# Altium schematic sheet Usb_To_UART.SchDoc(Usb_To_UART)
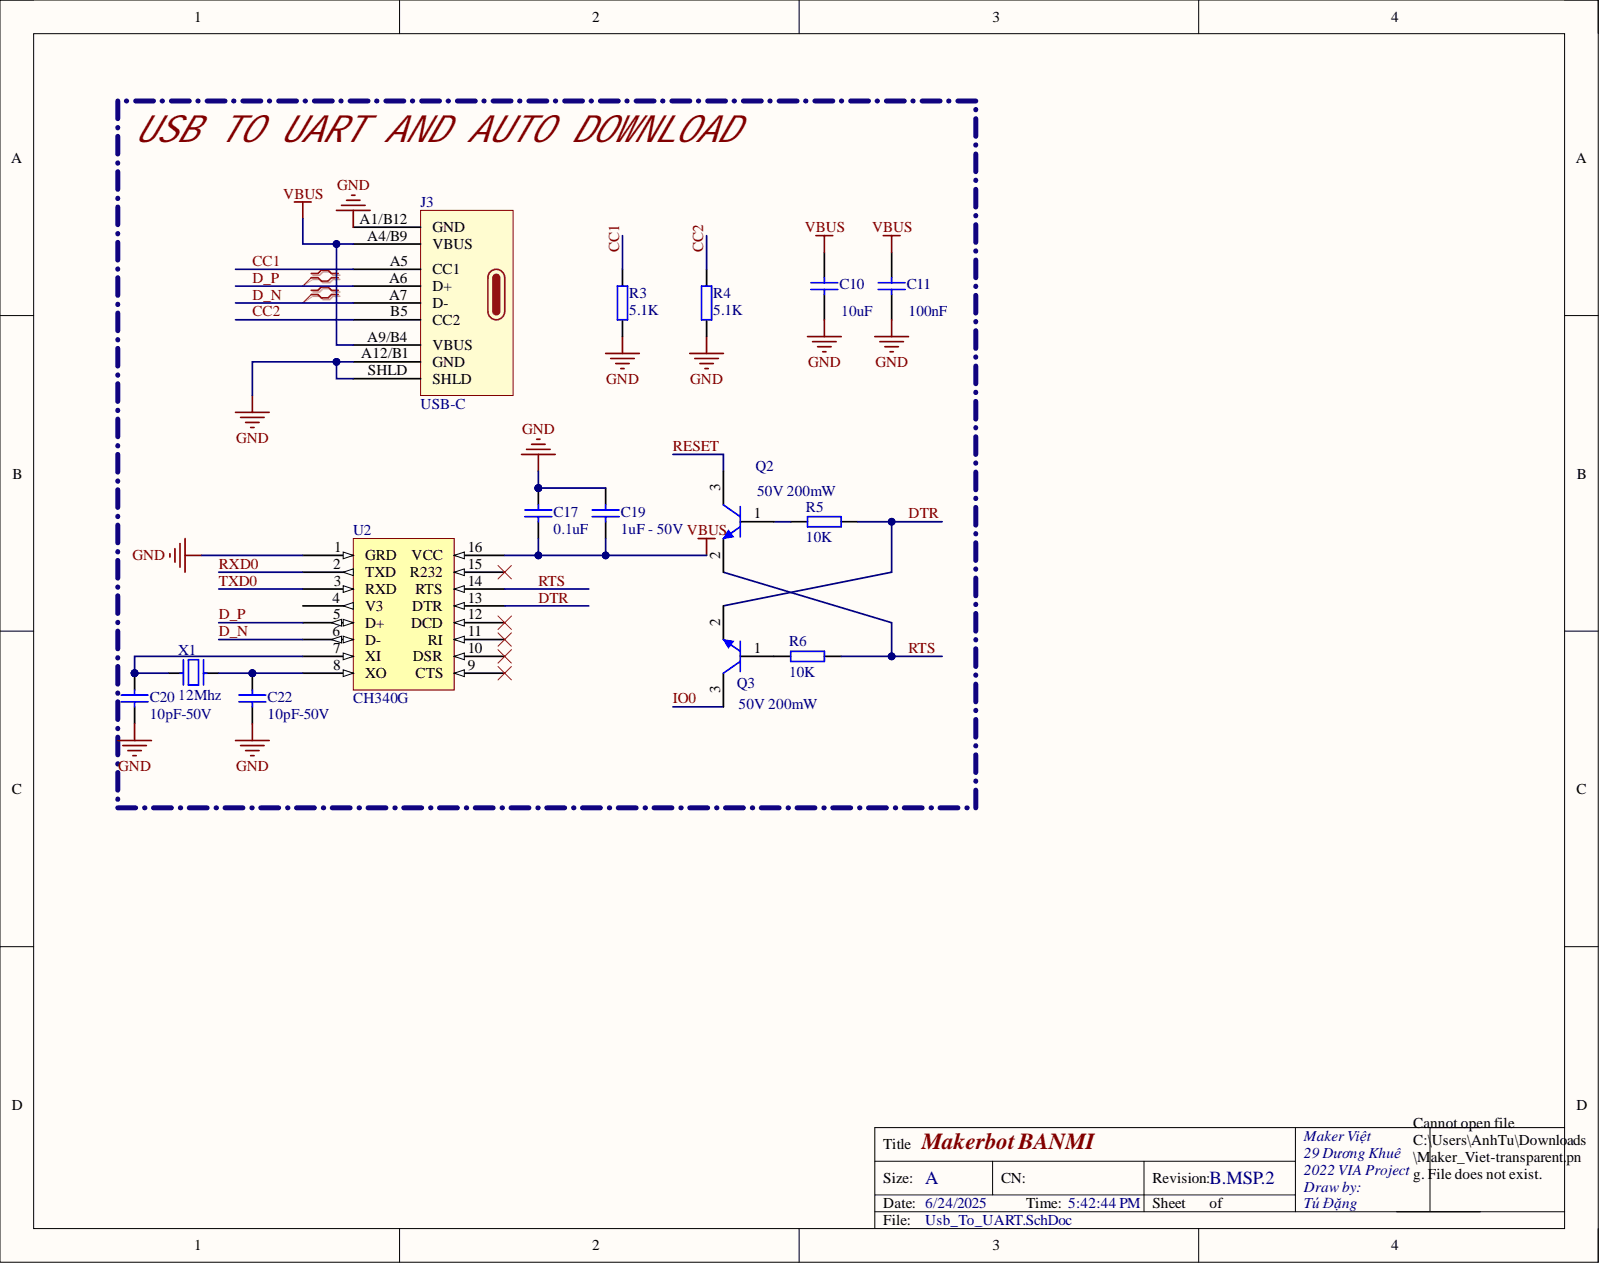
[diagram p0: Usb_To_UART.SchDoc]

## Parts
C10  value 10uF  (hidden comment: Cap Semi)
C11  value 100nF  (hidden comment: Cap Semi)
C17  0.1uF
C19  1uF - 50V
C20  10pF-50V
C22  10pF-50V
J3  USB-C
Q2  50V 200mW
Q3  50V 200mW
R3  value 5.1K  (hidden comment: Resistor)
R4  value 5.1K  (hidden comment: Resistor)
R5  value 10K  (hidden comment: Resistor)
R6  value 10K  (hidden comment: Resistor)
U2  CH340G
X1  12Mhz

## Nets
GND: C10.1 C11.1 C17.2 C19.2 C20.1 C22.1 J3.A1/B12(GND) J3.A12/B1(GND) J3.SHLD R3.2 R4.2 U2.1(GRD)
VBUS: C10.2 C11.2 C17.1 C19.1 J3.A4/B9(VBUS) J3.A9/B4(VBUS) U2.16(VCC)
CC1: J3.A5(CC1) R3.1
CC2: J3.B5(CC2) R4.1
D_N: J3.A7(D-) U2.6(D-)
D_P: J3.A6(D+) U2.5(D+)
DTR: Q3.2 R5.1 U2.13(DTR)
IO0: Q3.3
RESET: Q2.3
RTS: Q2.2 R6.1 U2.14(RTS)
RXD0: U2.2(TXD)
TXD0: U2.3(RXD)
NetC20_2: C20.2 U2.7(XI) X1.3
NetC22_2: C22.2 U2.8(XO) X1.1
NetQ2_1: Q2.1 R5.2
NetQ3_1: Q3.1 R6.2

Not connected: U2 4(V3) 9(CTS) 10(DSR) 11(RI) 12(DCD) 15(R232)

## Library data of the parts
C10 = CAP-SM-0603-X5R-10uF: CAP SM 0603 X5R 10uF 10%; Component Kind: Standard; Library Name: electronpowered.SchLib; Supplier 1: Farnell; Supplier 2: Digi-Key; Supplier Part Number 1: 2366833; Supplier Part Number 2: 490-3896-1-ND; Tolerance: ±10%
C11 = CAP-SM-0603-X7R-100nF: CAP SM 0603 X7R 100nF 10%; Component Kind: Standard; Library Name: electronpowered.SchLib; Supplier 1: Digi-Key; Supplier 2: Farnell; Supplier Part Number 1: 490-3167-1-ND; Supplier Part Number 2: 1775994RL; Tolerance: ±10%
C17 = C0603X7R104K500NT: CAP CER 0.1UF 50V X7R 0603; Manufacture: Hottech; Database Table Name: Components; Detailed Value: 0.1uF - 50V - 0603; PROJECT: KHO; Category: Capacitor; Component Kind: Standard; Mounting type: SMD; Partnumber: C0603X7R104K500NT; Value: 0.1uF; Creator: ThangND; Library Name: MKP_lib.DbLib
C19 = C0603X5R105K500NT: CAP CER 1UF 50V X5R 0603; Manufacture: Hottech; Database Table Name: Components; Detailed Value: 1uF - 50V - 0603; PROJECT: KHO; Category: Capacitor; Component Kind: Standard; Mounting type: SMD; Partnumber: C0603X5R105K500NT; Value: 1uF - 50V; Creator: ThangND; Library Name: MKP_lib.DbLib
C20 C22 = CC0603JRNPO9BN100: 50V 10pF NP0 ±5% 0603 Multilayer Ceramic Capacitors MLCC - SMD/SMT ROH; Manufacture: Yageo; Database Table Name: Components; Category: Capacitor; Component Kind: Standard; Detailed Value: 10pF-50V; Mounting type: SMD; Partnumber: CC0603JRNPO9BN100; Supplier: LCSC; Value: 10pF-50V; Library Name: MKP_lib.DbLib
Q2 Q3 = C1815: 50V 200mW 200@2mA,6V 150mA SOT-23 Bipolar Transistors - BJT ROHS; Manufacture: CBI  CBI; Database Table Name: Components; Link sale: #https://www.lcsc.com/product-detail/Bipolar-Transistors-BJT_CBI-C1815_C2828450.html#; Category: Transistor; Component Kind: Standard; Detailed Value: 50V 200mW; Mounting type: Surface Mount; Partnumber: C1815; Supplier: LCSC; Value: 50V 200mW; Library Name: MKP_lib.DbLib
R3-R6 = RES-SM-0603-10K: RES SM 0603 10K; Component Kind: Standard; Supplier 1: Farnell; Supplier 2: Digi-Key; Supplier Part Number 1: 9330399; Supplier Part Number 2: 541-10.0KHCT-ND
  R5 R6: Library Name: electronpowered.SchLib
  R3 R4: Library Name: WIle_dev_kit.SCHLIB
U2 = CH340G: 2Mbps Transceiver USB 2.0 SOP-16 USB ICs ROHS; Database Table Name: Components; Category: IC; Component Kind: Standard; Manufacture: 2Mbps Transceiver USB 2.0 SOP-16 USB ICs ROHS; Mounting type: SMD; Partnumber: CH340G; Value: CH340G; Creator: Tunn; Library Name: MKP_lib.DbLib
X1 = X322512MSB4SI: Manufacture: Yangxing Tech; Database Table Name: Components; Category: Crystal; Component Kind: Standard; Description: X322512MSB4SI; Mounting type: SMD; Partnumber: X322512MSB4SI; Value: 12Mhz; Creator: Tunn; Library Name: MKP_lib.DbLib
J3 = USB-C: Component Kind: Standard; Library Name: WIle_dev_kit.SCHLIB
